# Altium schematic sheet SchematicAUTO.SchDoc(SchematicAUTO)
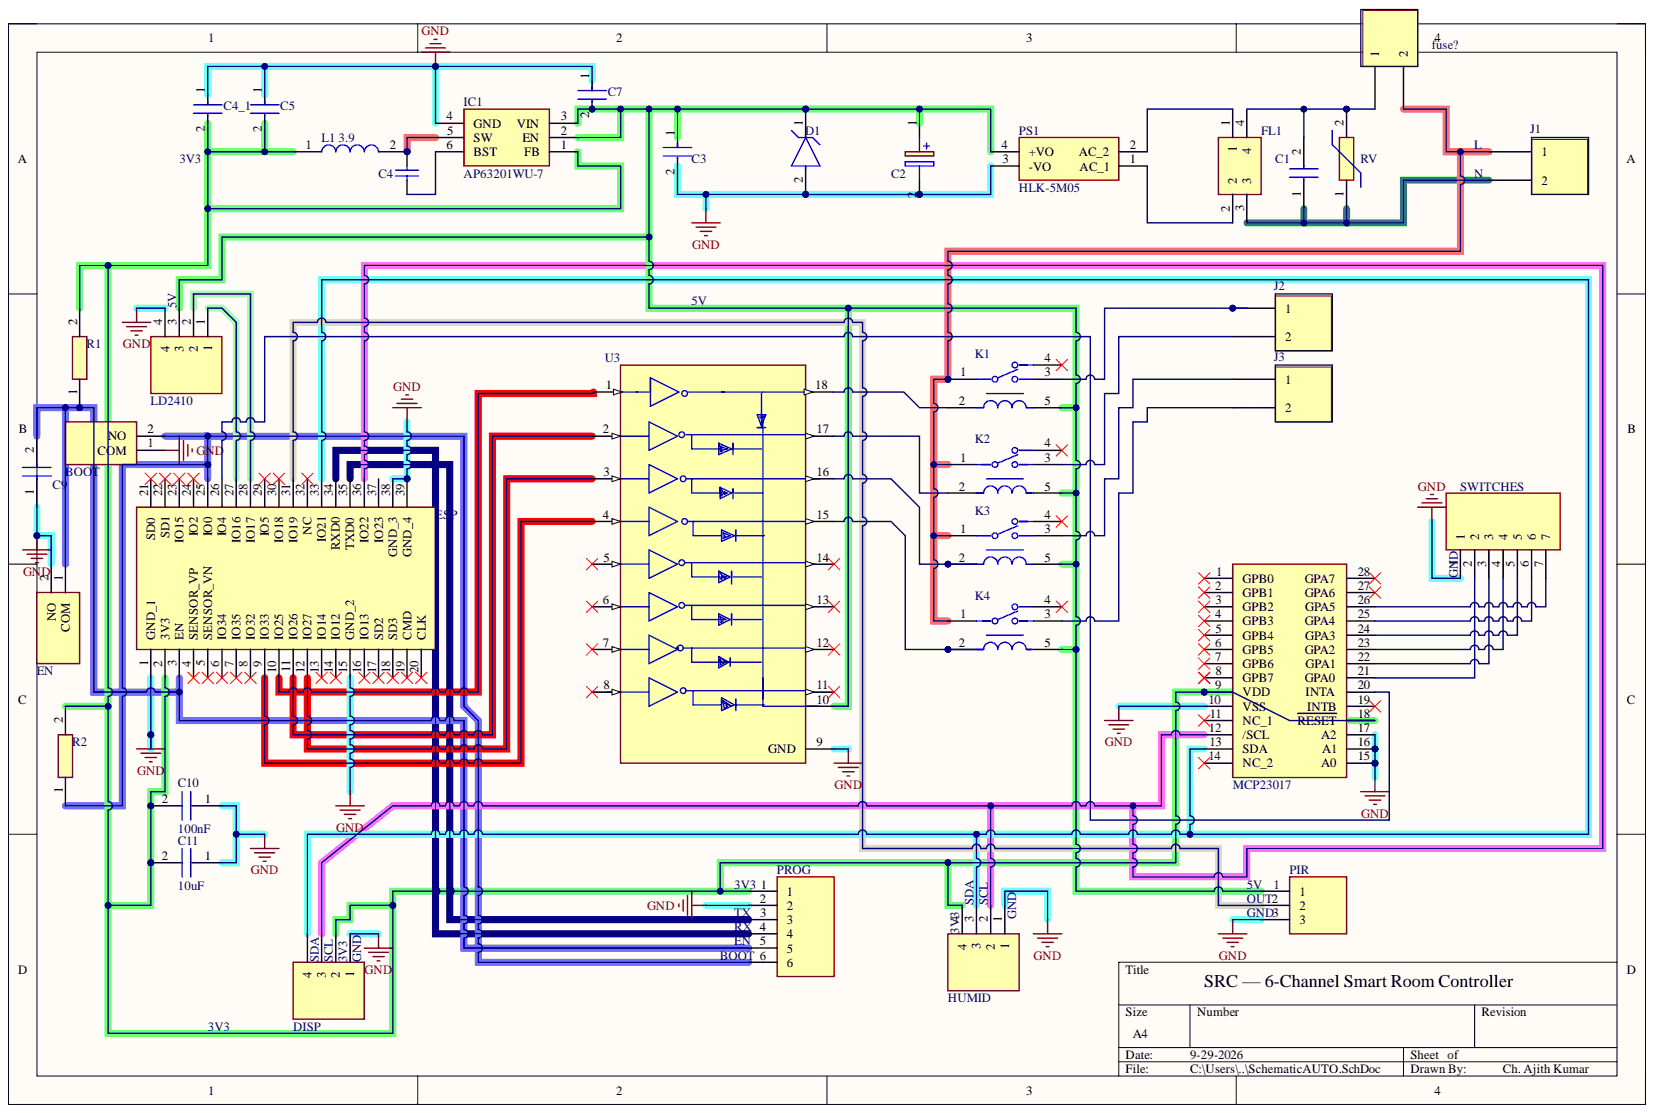
[diagram p0: SchematicAUTO.SchDoc]

## Parts
BOOT  TS-1088-AR02016  [TS1088AR02016]  (value not drawn)
C1  R46KI310050M1K  [R46KI310050M1K]  (value not drawn)
C2  CA-1HHG221  [CAPPRD500W65D1000H1350]  (value not drawn)
C3  GRM31CR71E106KA12L  [FP-GRM31C-0_2-e0_3_0_8-MFG]  (value not drawn)
C4  GRM188R71H104KA93D  [CAPC1608X90X35ML10T15]  (value not drawn)
C4_1  GRM31CR71A226KE15L  [FP-GRM31C-0_2-e0_3_0_8-MFG]  (value not drawn)
C5  GRM31CR71A226KE15L  [FP-GRM31C-0_2-e0_3_0_8-MFG]  (value not drawn)
C7  GRM32ER71H106KA12L  [FP-GRM32-MFG]  (value not drawn)
C9  GRM188R71H104KA93D  [CAPC1608X90N]  (value not drawn)
C10  100nF  [CAPC1608X90N]
C11  10uF  [CAPC2012X145N]
D1  SMBJ5.0A  [FP-SMBJ-MFG]  (value not drawn)
DISP  B4B-XH-A_LF__SN_  [B4BXHALFSN]  (value not drawn)
EN  TS-1088-AR02016  [TS1088AR02016]  (value not drawn)
ESP  ESP32-WROOM-32D  [ESP32WROOM32D]  (value not drawn)
FL1  B82791G2501N001  [B82791G2501N001]  (value not drawn)
fuse?  SCREW TERMINAL AC  [SCREW TERMINAL]  (value not drawn)
HUMID  B4B-XH-A_LF__SN_  [B4BXHALFSN]  (value not drawn)
IC1  AP63201WU-7  [SOT95P280X100-6N]
J1  SCREW TERMINAL AC  [SCREW TERMINAL]  (value not drawn)
J2  SCREW TERMINAL AC  [SCREW TERMINAL]  (value not drawn)
J3  SCREW TERMINAL AC  [SCREW TERMINAL]  (value not drawn)
K1  G5LE-1-E-36-DC5  [FP-G5LE-1-E-36-DC5-MFG]  (value not drawn)
K2  G5LE-1-E-36-DC5  [FP-G5LE-1-E-36-DC5-MFG]  (value not drawn)
K3  G5LE-1-E-36-DC5  [FP-G5LE-1-E-36-DC5-MFG]  (value not drawn)
K4  G5LE-1-E-36-DC5  [FP-G5LE-1-E-36-DC5-MFG]  (value not drawn)
L1_3.9  ASPI-0628-3R9M-T1  [ASPI0628150MT1]  (value not drawn)
LD2410  B4B-XH-A_LF__SN_  [B4BXHALFSN]  (value not drawn)
MCP23017  MCP23017T-E_SO  [SOIC127P1030X265-28N]  (value not drawn)
PIR  B3B-XH-A_LF__SN_  [B3BXHALFSN]  (value not drawn)
PROG  B6B-XH-A_LF__SN_  [SHDR6W64P0X250_1X6_1740X575X700P]  (value not drawn)
PS1  HLK-5M05  [HLK5M05]
R1  RC0603FR0710KL  [RESC1608X55N]  (value not drawn)
R2  RC0603FR0710KL  [RESC1608X55N]  (value not drawn)
RV  MOV-10D561K  [MOV10D561K]  (value not drawn)
SWITCHES  B7B-XH-A_LF__SN_  [SHDR7W64P0X250_1X7_1990X575X700P]  (value not drawn)
U3  ULN2803ADWRG4  [FP-DW0018A-MFG]  (value not drawn)

## Nets
GND: BOOT.1 C2.2 C3.2 C4_1.1 C5.1 C7.1 C9.1 C10.1 C11.1 D1.2 DISP.1 EN.2 ESP.1(GND_1) ESP.15(GND_2) ESP.38(GND_3) ESP.39(GND_4) HUMID.1 IC1.4(GND) LD2410.4 MCP23017.10(VSS) MCP23017.15(A0) MCP23017.16(A1) MCP23017.17(A2) PIR.3 PROG.2 PS1.3(-VO) SWITCHES.1 U3.9(GND)
NetBOOT_2: BOOT.2 ESP.25(IO0) PROG.6 R2.1
NetC1_1: C1.1 FL1.3 J1.2 RV.1
NetC1_2: C1.2 FL1.4 fuse?.1 RV.2
NetC2_1: C2.1 C3.1 C7.2 D1.1 IC1.2(EN) IC1.3(VIN) K1.5 K2.5 K3.5 K4.5 LD2410.3 PIR.1 PS1.4(+VO) U3.10
NetC4_1: C4.1 IC1.6(BST)
NetC4_1_2: C4_1.2 C5.2 C10.2 C11.2 DISP.2 ESP.2(3V3) HUMID.4 IC1.1(FB) L1_3.9.1 MCP23017.9(VDD) MCP23017.18(RESET) PROG.1 R1.2 R2.2
NetC4_2: C4.2 IC1.5(SW) L1_3.9.2
NetC9_2: C9.2 EN.1 ESP.3(EN) PROG.5 R1.1
NetDISP_3: DISP.3 ESP.36(IO22) HUMID.2 MCP23017.12(/SCL)
NetDISP_4: DISP.4 ESP.33(IO21) HUMID.3 MCP23017.13(SDA)
NetESP_9: ESP.9(IO33) U3.4
NetESP_10: ESP.10(IO25) U3.1
NetESP_11: ESP.11(IO26) U3.2
NetESP_12: ESP.12(IO27) U3.3
NetESP_26: ESP.26(IO4) MCP23017.20(INTA)
NetESP_27: ESP.27(IO16) LD2410.1
NetESP_28: ESP.28(IO17) LD2410.2
NetESP_31: ESP.31(IO19) PIR.2
NetESP_34: ESP.34(RXD0) PROG.4
NetESP_35: ESP.35(TXD0) PROG.3
NetFL1_1: FL1.1 PS1.2(AC_2)
NetFL1_2: FL1.2 PS1.1(AC_1)
Netfuse?_2: fuse?.2 J1.1 K1.1 K2.1 K3.1 K4.1
NetJ2_1: J2.1 K1.3
NetJ2_2: J2.2 K2.3
NetJ3_1: J3.1 K3.3
NetJ3_2: J3.2 K4.3
NetK1_2: K1.2 U3.18
NetK2_2: K2.2 U3.17
NetK3_2: K3.2 U3.16
NetK4_2: K4.2 U3.15
NetMCP23017_21: MCP23017.21(GPA0) SWITCHES.2
NetMCP23017_22: MCP23017.22(GPA1) SWITCHES.3
NetMCP23017_23: MCP23017.23(GPA2) SWITCHES.4
NetMCP23017_24: MCP23017.24(GPA3) SWITCHES.5
NetMCP23017_25: MCP23017.25(GPA4) SWITCHES.6
NetMCP23017_26: MCP23017.26(GPA5) SWITCHES.7

Not connected: ESP 4(SENSOR_VP) 5(SENSOR_VN) 6(IO34) 7(IO35) 8(IO32) 13(IO14) 14(IO12) 16(IO13) 17(SD2) 18(SD3) 19(CMD) 20(CLK) 21(SD0) 22(SD1) 23(IO15) 24(IO2) 29(IO5) 30(IO18) 32(NC) 37(IO23); K1 4; K2 4; K3 4; K4 4; MCP23017 1(GPB0) 2(GPB1) 3(GPB2) 4(GPB3) 5(GPB4) 6(GPB5) 7(GPB6) 8(GPB7) 11(NC_1) 14(NC_2) 19(INTB) 27(GPA6) 28(GPA7); U3 5 6 7 8 11 12 13 14

## Library data of the parts
BOOT EN = TS-1088-AR02016: Switch; Manufacturer_Name: XUNPU; Component Kind: Standard; Datasheet Link: https://www.xunpudianzi.com/uploads/pdf/TS-1088-ARXXX.pdf; Height: 2mm; Manufacturer_Part_Number: TS-1088-AR02016
C1 = R46KI310050M1K: Capacitor; Manufacturer_Name: Yageo Group; Arrow Part Number: R46KI310050M1K; Arrow Price/Stock: https://www.arrow.com/en/products/r46ki310050m1k/kemet-corporation?utm_currency=USD&region=nac; Component Kind: Standard; Datasheet Link: https://www.yageogroup.com/content/datasheet/asset/file/KEM_F3093_R46_X2_275_110C; Height: 11.1mm; Manufacturer_Part_Number: R46KI310050M1K; Mouser Part Number: 80-R46KI310050M1K; Mouser Price/Stock: https://www.mouser.co.uk/ProductDetail/KEMET/R46KI310050M1K/?qs=D0iH%252BVFiYPMp3c4CZzIXlA%3D%3D
C2 = ECA-1HHG221: Capacitor Polarised; Manufacturer_Name: Panasonic; Arrow Part Number: ECA-1HHG221; Arrow Price/Stock: https://www.arrow.com/en/products/eca-1hhg221/panasonic?region=europe; Component Kind: Standard; Datasheet Link: http://industrial.panasonic.com/cdbs/www-data/pdf/RDF0000/ABA0000C1215.pdf; Height: 13.5mm; Manufacturer_Part_Number: ECA-1HHG221; Mouser Part Number: 667-ECA-1HHG221; Mouser Price/Stock: https://www.mouser.co.uk/ProductDetail/Panasonic/ECA-1HHG221?qs=nDLeKX6TjskSDJeW4s110g%3D%3D
C3 = CMP-06035-003589-1: Chip Multilayer Ceramic Capacitors for General Purpose, 1206, 10uF, X7R, 15%, 10%, 25V; Capacitance: 10uF; Case Code (Imperial): 1206; Case Code (Metric): 3216; Case/Package: 1206; Component Kind: Standard; Depth: 1.6mm; Dielectric: X7R; Height: 1.6mm; Lead Free: Lead Free; Length: 3.2mm; Library Name: Altium Content Vault; Material: Ceramic; Max Operating Temperature: 125°C; Min Operating Temperature: -55°C; Mount: Surface Mount; Number of Pins: 2; Package Quantity: 1; Packaging: Tape and Reel; Radiation Hardening: No; REACH SVHC: No SVHC; Termination: SMD/SMT; Thickness: 0.071inch; Tolerance: 10%; Value: 10uF; Voltage: 25V; Voltage Rating: 25V; Voltage Rating (DC): 25V; Width: 1.6mm
C4 = CMP-2100-03643-1: Chip Capacitor, 0.1 uF, +/- 10%, 50 V, -55 to 125 degC, 0603 (1608 Metric), RoHS, Tape and Reel; Manufacturer: Murata; Capacitance: 100nF; Dielectric: X7R; Dielectric Material: Ceramic; Insulation Resistance: 10GR; Value: 100nF; Voltage: 50V; Case Code (Imperial): 0603; Case Code (Metric): 1608; Case/Package: 0603; Component Kind: Standard; Contact Plating: Tin; Datasheet URL: http://psearch.en.murata.com/capacitor/product/GRM188R71H104KA93%23.pdf; Datasheet Version: 42675.0; Depth: 0.8mm; Height: 0.8mm; Lead Free: LeadFree; Length: 1.6mm; Library Name: Altium Content Vault; Manufacturer URL: http://www.murata.com/; Material: Ceramic; Max Operating Temperature: 125degC; Min Operating Temperature: -55degC; Mount: SurfaceMount; Number of Pins: 2; Package Description: 2-Pin Surface Mount Device, Body 1.6 x 0.8 mm; Package Quantity: 1; Package Reference: 0603; Packaging: TapeandReel; Radiation Hardening: No; REACH SVHC: NoSVHC; RoHSCompliant: true; Termination: SMD/SMT; Thickness: 0.035inch; Tolerance: 10%; Voltage Rating: 50V; Voltage Rating (DC): 50V; Width: 0.8mm
C4_1 C5 = CMP-06035-003841-1: Chip Multilayer Ceramic Capacitors for General Purpose, 1206, 22uF, X7R, 15%, 10%, 10V; Capacitance: 22uF; Case Code (Imperial): 1206; Case Code (Metric): 3216; Case/Package: 1206; Component Kind: Standard; Depth: 1.6mm; Dielectric: X7R; Height: 1.6mm; Lead Free: Lead Free; Length: 3.2mm; Library Name: Altium Content Vault; Material: Ceramic; Max Operating Temperature: 125°C; Min Operating Temperature: -55°C; Mount: Surface Mount; Package Quantity: 1; Packaging: Tape and Reel; Radiation Hardening: No; REACH SVHC: No SVHC; Termination: SMD/SMT; Thickness: 0.071inch; Tolerance: 10%; Value: 22uF; Voltage: 10V; Voltage Rating: 10V; Voltage Rating (DC): 10V; Width: 0.063inch
C7 = CMP-2000-05261-2: CAP CER 10UF 50V X7R 1210; Manufacturer: Murata; Material: Ceramic; Temperature Coefficient: 15%; Tolerance: 10%; Automotive: No; Capacitance: 10uF; Category: Cap; Component Kind: Standard; ComponentLink2Description: Datasheet; ComponentLink2URL: https://search.murata.co.jp/Ceramy/image/img/A01X/G101/ENG/GRM32ER71H106KA12-01.pdf; Device Class L1: Passive Components; Device Class L2: Capacitors; Device Class L3: Ceramic Capacitors; Digikey Description: CAP CER 10UF 50V X7R 1210; Height: 2.7mm; Lead Free: Yes; Library Name: Altium Content Vault; Manufacturer Part Number: GRM32ER71H106KA12L; Mouser Description: 1210 10 uF 50 V ±10% Tolerance X7R SMT Multilayer Ceramic Capacitor; Octopart: https://octopart.com/grm32er71h106ka12l-murata-10470987; Package: GRM32; RoHS: TRUE; Temperature: -55°C to +125°C; Temperature Characteristic: X7R; Temperature Range High: +125°C; Temperature Range Low: -55°C; Value: 10uF; Voltage Rating: 50V
C9 = GRM188R71H104KA93D: Capacitor; Manufacturer_Name: Murata Electronics; Arrow Part Number: GRM188R71H104KA93D; Arrow Price/Stock: https://www.arrow.com/en/products/grm188r71h104ka93d/murata-manufacturing.html?utm_currency=USD&region=europe; Component Kind: Standard; Datasheet Link: https://pim.murata.com/en-sg/pim/details/?partNum=GRM188R71H104KA93%23&displayChangeClass=productDetailPrint; Height: 0.9mm; Manufacturer_Part_Number: GRM188R71H104KA93D; Mouser Part Number: 81-GRM39X104K50D; Mouser Price/Stock: https://www.mouser.co.uk/ProductDetail/Murata-Electronics/GRM188R71H104KA93D?qs=f7ZljCwbPOm85Y6l%2FNGt7A%3D%3D
C10 = CC0603KRX7R9BB104: Capacitor; Manufacturer_Name: Yageo Group; Arrow Part Number: CC0603KRX7R9BB104; Arrow Price/Stock: https://www.arrow.com/en/products/cc0603krx7r9bb104/yageo.html?utm_currency=USD&region=nac; Component Kind: Standard; Datasheet Link: https://www.digikey.com/en/products/detail/yageo/CC0603KRX7R9BB104/2103082; Height: 0.9mm; Manufacturer_Part_Number: CC0603KRX7R9BB104; Mouser Part Number: 603-CC603KRX7R9BB104; Mouser Price/Stock: https://www.mouser.co.uk/ProductDetail/YAGEO/CC0603KRX7R9BB104?qs=vTakOoo5QyLvVCYM2ge8LQ%3D%3D
C11 = GRM21BR71A106KE51L: Capacitor; Manufacturer_Name: Murata Electronics; Arrow Part Number: GRM21BR71A106KE51L; Arrow Price/Stock: https://www.arrow.com/en/products/grm21br71a106ke51l/murata-manufacturing; Component Kind: Standard; Datasheet Link: https://search.murata.co.jp/Ceramy/image/img/A01X/G101/ENG/GRM21BR71A106KE51-01.pdf; Height: 1.45mm; Manufacturer_Part_Number: GRM21BR71A106KE51L; Mouser Part Number: 81-GRM21BR71A106KE51; Mouser Price/Stock: https://www.mouser.co.uk/ProductDetail/Murata-Electronics/GRM21BR71A106KE51L?qs=kHCDrmfBqSAdNFLacabF8w%3D%3D
D1 = CMP-07401-000003-1: TVS DIODE 5V 9.2V SMB; Manufacturer: Bourns Inc.; Breakdown Voltage: 6.4V; Direction: Unidirectional; Max Junction Temp: +150°C; Number Of Channels: 1; Peak Pulse Current: 65.3A; Peak Pulse Power: 600W; Standoff Height: 0.05mm; Automotive: No; Category: Diode; Clamping Voltage: 9.2V; Component Kind: Standard; ComponentLink2Description: Datasheet; ComponentLink2URL: https://www.bourns.com/docs/Product-Datasheets/SMBJ.pdf; Device Class L1: Circuit Protection; Device Class L2: TVS Diodes; Device Class L3: unset; Digikey Description: TVS DIODE 5V 9.2V SMB; Height: 2.44mm; Lead Free: Yes; Library Name: Altium Content Vault; Manufacturer Part Number: SMBJ5.0A; Mouser Description: Dio Tvs Vwm 5.0V 600W Unidir Smb Rohs Compliant: Yes; Octopart: https://octopart.com/smbj5.0a-bourns-12809487; Package: SMBJ; Reverse Standoff Voltage: 5V; RoHS: TRUE; Temperature: -55°C to +150°C; Temperature Range High: +150°C; Temperature Range Low: -55°C
DISP HUMID LD2410 = B4B-XH-A_LF__SN_: Connector; Manufacturer_Name: JST (JAPAN SOLDERLESS TERMINALS); Manufacturer_Part_Number: B4B-XH-A(LF)(SN); Arrow Part Number: B4B-XH-A(LF)(SN); Arrow Price/Stock: https://www.arrow.com/en/products/b4b-xh-a-lf-sn/jst-manufacturing.html?utm_currency=USD&region=europe; Component Kind: Standard; Datasheet Link: https://datasheet.lcsc.com/szlcsc/JST-Sales-America-B4B-XH-A-LF-SN_C144395.pdf; Height: 7mm; Mouser Part Number: 306-B4BXHALFSN; Mouser Price/Stock: https://www.mouser.co.uk/ProductDetail/JST-Commercial/B4B-XH-ALFSN?qs=cdbOS8ANM9CfC08d%252BywhFw%3D%3D
ESP = ESP32-WROOM-32D: Antenna; Manufacturer_Name: Espressif Systems; Component Kind: Standard; Datasheet Link: https://www.mouser.com/datasheet/2/891/esp32-wroom-32d_esp32-wroom-32u_datasheet_en-1365844.pdf; Height: 3.1mm; Manufacturer_Part_Number: ESP32-WROOM-32D; Mouser Part Number: N/A; Mouser Price/Stock: https://www.mouser.co.uk/ProductDetail/Espressif-Systems/ESP32-WROOM-32D?qs=MLItCLRbWszx2KabkKPu5A%3D%3D
FL1 = B82791G2501N001: Filter; Manufacturer_Name: TDK; Component Kind: Standard; Datasheet Link: https://product.tdk.com/system/files/dam/doc/product/emc/emc/line-filter/data_sheet/30/db/ind_2008/b82791g_h_k.pdf; Height: 8mm; Manufacturer_Part_Number: B82791G2501N001; Mouser Part Number: 871-B82791G2501N001; Mouser Price/Stock: https://www.mouser.co.uk/ProductDetail/EPCOS-TDK/B82791G2501N001?qs=x3Z3TaiycdvV0L6IX0jwrA%3D%3D
IC1 = AP63201WU-7: Integrated Circuit; Manufacturer_Name: Diodes Incorporated; Arrow Part Number: AP63201WU-7; Arrow Price/Stock: https://www.arrow.com/en/products/ap63201wu-7/diodes-incorporated?utm_currency=USD&region=nac; Component Kind: Standard; Datasheet Link: https://www.diodes.com//assets/Datasheets/AP63200-AP63201-AP63203-AP63205.pdf; Height: 1mm; Manufacturer_Part_Number: AP63201WU-7; Mouser Part Number: 621-AP63201WU-7; Mouser Price/Stock: https://www.mouser.co.uk/ProductDetail/Diodes-Incorporated/AP63201WU-7?qs=u16ybLDytRaVD%252BU3eG30NA%3D%3D
K1-K4 = CMP-08822-000056-1: RELAY GEN PURPOSE SPDT 16A 5V; Coil Current: 72mA; Coil Power: 360mW; Coil Resistance: 70R; Coil Type: Non-Latching; Operate Time: 10ms; Power Consumption: 400mW; Relay Type: General Purpose; Release Time: 5ms; Coil Voltage (DC): 5V; Component Kind: Standard; Contact Current Rating: 16A; Contact Material: Tin; Library Name: Altium Content Vault; Max Operating Temperature: 85°C; Min Operating Temperature: -40°C; Mount: Through Hole; Package Quantity: 100; Packaging: Tray; Series: G5LE-E; Termination: PC Pin; Throw Configuration: SPDT
L1_3.9 = ASPI-0628-3R9M-T1: Inductor; Manufacturer_Name: ABRACON; Arrow Part Number: ASPI-0628-3R9M-T1; Arrow Price/Stock: https://www.arrow.com/en/products/aspi-0628-3r9m-t1/abracon?region=europe; Component Kind: Standard; Datasheet Link: https://abracon.com/Magnetics/new/ASPI-0628.pdf; Height: 2.8mm; Manufacturer_Part_Number: ASPI-0628-3R9M-T1; Mouser Part Number: 815-ASPI-0628-3R9MT1; Mouser Price/Stock: https://www.mouser.co.uk/ProductDetail/ABRACON/ASPI-0628-3R9M-T1?qs=7Oetya%252B4D2Cz7OuGoDTYww%3D%3D
MCP23017 = MCP23017T-E_SO: Integrated Circuit; Manufacturer_Name: Microchip; Manufacturer_Part_Number: MCP23017T-E/SO; Arrow Part Number: MCP23017T-E/SO; Arrow Price/Stock: https://www.arrow.com/en/products/mcp23017t-eso/microchip-technology.html?utm_currency=USD&region=nac; Component Kind: Standard; Datasheet Link: http://ww1.microchip.com/downloads/en/DeviceDoc/20001952C.pdf; Height: 2.65mm; Mouser Part Number: 579-MCP23017T-E/SO; Mouser Price/Stock: https://www.mouser.co.uk/ProductDetail/Microchip-Technology/MCP23017T-E-SO?qs=usxtMOJb1RylPfT%252BJtgE3Q%3D%3D
PIR = B3B-XH-A_LF__SN_: Connector; Manufacturer_Name: JST (JAPAN SOLDERLESS TERMINALS); Manufacturer_Part_Number: B3B-XH-A(LF)(SN); Arrow Part Number: B3B-XH-A(LF)(SN); Arrow Price/Stock: https://www.arrow.com/en/products/b3b-xh-a-lf-sn/jst-manufacturing.html?utm_currency=USD&region=europe; Component Kind: Standard; Datasheet Link: https://datasheet.lcsc.com/szlcsc/1812131720_JST-Sales-America-B3B-XH-A-LF-SN_C144394.pdf; Height: 7mm; Mouser Part Number: 306-B3BXHALFSNP; Mouser Price/Stock: https://www.mouser.co.uk/ProductDetail/JST-Commercial/B3B-XH-ALFSN?qs=cdbOS8ANM9BVkoboCCIEMQ%3D%3D
PROG = B6B-XH-A_LF__SN_: Connector; Manufacturer_Name: JST (JAPAN SOLDERLESS TERMINALS); Manufacturer_Part_Number: B6B-XH-A(LF)(SN); Arrow Part Number: B6B-XH-A(LF)(SN); Arrow Price/Stock: https://www.arrow.com/en/products/b6b-xh-a-lf-sn/jst-manufacturing.html?utm_currency=USD&region=europe; Component Kind: Standard; Datasheet Link: https://datasheet.lcsc.com/szlcsc/JST-Sales-America-B6B-XH-A-LF-SN_C144397.pdf; Height: 7mm; Mouser Part Number: 306-B6BXHALFSN; Mouser Price/Stock: https://www.mouser.co.uk/ProductDetail/JST-Commercial/B6B-XH-ALFSN?qs=cdbOS8ANM9AKmMmofXbwlw%3D%3D
PS1 = HLK-5M05: Power Supply; Manufacturer_Name: Hi-Link; Component Kind: Standard; Datasheet Link: http://www.hlktech.net/product_detail.php?ProId=60; Height: 18mm; Manufacturer_Part_Number: HLK-5M05
R1 R2 = RC0603FR0710KL: Resistor; Manufacturer_Name: Yageo Group; Arrow Part Number: RC0603FR0710KL; Arrow Price/Stock: https://www.arrow.com/en/products/rc0603fr-0710kl/yageo; Component Kind: Standard; Datasheet Link: http://www.yageo.com/documents/recent/PYu-RC0603_51_RoHS_L_v5.pdf; Height: 0.55mm; Manufacturer_Part_Number: RC0603FR0710KL
RV = MOV-10D561K: Varistor; Manufacturer_Name: Bourns; Arrow Part Number: MOV-10D561K; Arrow Price/Stock: https://www.arrow.com/en/products/mov-10d561k/bourns.html?utm_currency=USD&region=europe; Component Kind: Standard; Datasheet Link: https://www.bourns.com/docs/Product-Datasheets/MOV10D.pdf; Height: 15.5mm; Manufacturer_Part_Number: MOV-10D561K; Mouser Part Number: 652-MOV-10D561K; Mouser Price/Stock: https://www.mouser.co.uk/ProductDetail/Bourns/MOV-10D561K?qs=lgttKnAd%252B2ArrqGQgWwr6w%3D%3D
SWITCHES = B7B-XH-A_LF__SN_: Connector; Manufacturer_Name: JST (JAPAN SOLDERLESS TERMINALS); Manufacturer_Part_Number: B7B-XH-A(LF)(SN); Arrow Part Number: B7B-XH-A(LF)(SN); Arrow Price/Stock: https://www.arrow.com/en/products/b7b-xh-a-lf-sn/jst-manufacturing?utm_currency=USD&region=europe; Component Kind: Standard; Datasheet Link: https://datasheet.lcsc.com/szlcsc/JST-Sales-America-B7B-XH-A-LF-SN_C144398.pdf; Height: 7mm; Mouser Part Number: 306-B7BXHALFSNP; Mouser Price/Stock: https://www.mouser.co.uk/ProductDetail/JST-Commercial/B7B-XH-ALFSN?qs=cdbOS8ANM9DLeRSemn13Pg%3D%3D
U3 = CMP-04912-000023-1: TRANS 8NPN DARL 50V 0.5A 18SO; Manufacturer: Texas Instruments; Max Junction Temp: +150°C; Nominal Supply Current: 0.93mA; Number Of Drivers: 8; Output Current: 500mA; Standoff Height: 0.1mm; Turn Off Delay Time: 130ns; Turn On Delay Time: 20ns; Ambient Temperature Range High: +85°C; Ambient Temperature Range Low: -40°C; Automotive: No; Category: IC; Component Kind: Standard; ComponentLink2Description: Datasheet; ComponentLink2URL: http://www.ti.com/lit/ds/symlink/uln2803a.pdf; Device Class L1: Integrated Circuits (ICs); Device Class L2: Power Management ICs; Device Class L3: Gate Drivers; Digikey Description: TRANS 8NPN DARL 50V 0.5A 18SO; Height: 2.65mm; Ipc Land Pattern Name: SOIC127P1030X265-18; Lead Free: Yes; Library Name: Altium Content Vault; Manufacturer Part Number: ULN2803ADWRG4; Mouser Description: Darlington Transistors TRANSISTOR ARRAYS; Number Of Outputs: 8; Octopart: https://octopart.com/uln2803adwrg4-texas+instruments-2343640; Output Voltage: 50V; Package: DW0018A; RoHS: TRUE; Temperature: -40°C to +85°C; Temperature Range High: +85°C; Temperature Range Low: -40°C
fuse? J1-J3 = SCREW TERMINAL AC: Component Kind: Standard; Library Name: SamacSys.SchLib
